# Altium schematic sheet Power.SchDoc
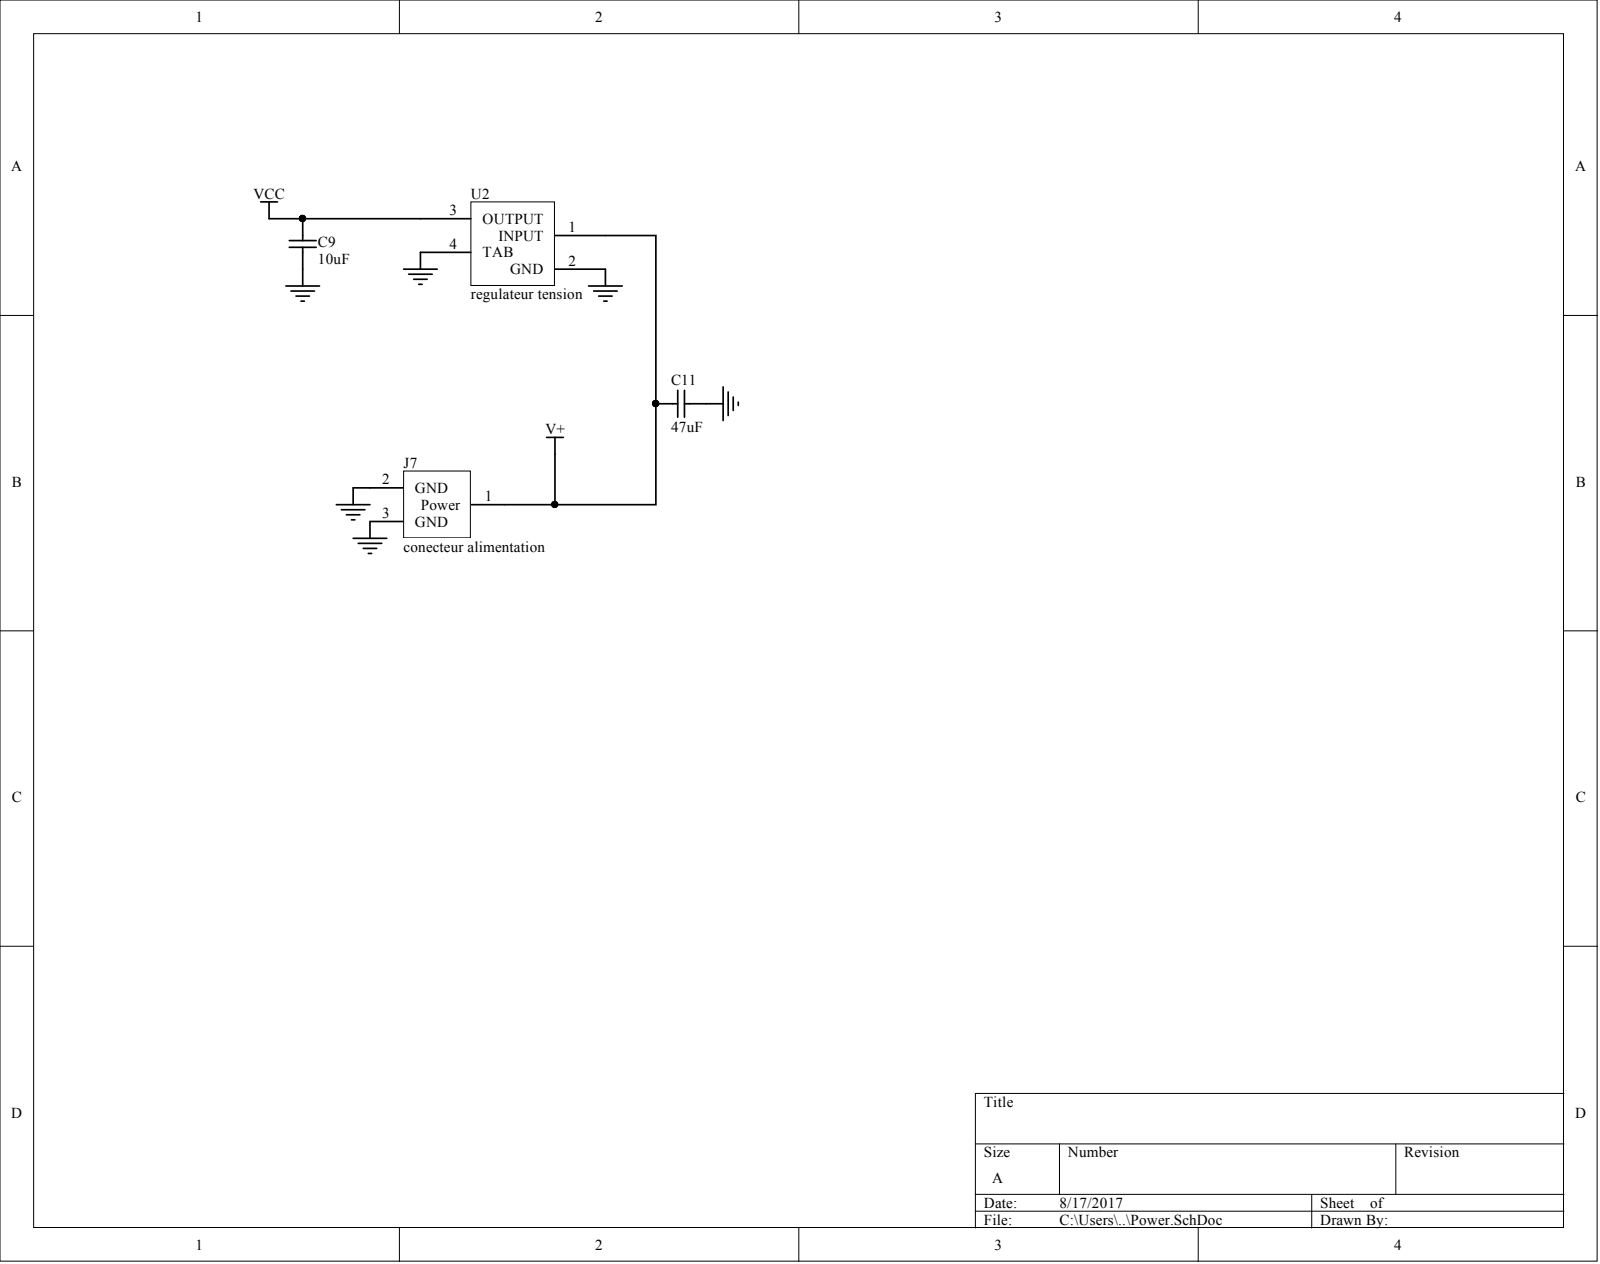
[diagram p0: Power.SchDoc]

## Parts
C9  10uF  [CAPC1608X09N]
C11  47uF  [CAPC1608X09N]
J7  conecteur alimentation
U2  regulateur tension  [SOT230P710X180-4N]

## Nets
GND: C9.1 C11.1 J7.2 J7.3 U2.2(GND) U2.4(TAB)
V+: C11.2 J7.1 U2.1(INPUT)
VCC: C9.2 U2.3(OUTPUT)

## Library data of the parts
C9 C11 = Cap: Capacitor; Value: 0.1uf; Component Kind: Standard; LatestRevisionDate: 17-Jul-2002; LatestRevisionNote: Re-released for DXP Platform.; Library Name: Miscellaneous Devices.IntLib; PackageDescription: Radial Cap, Thru-Hole; 2 Leads; 0.3 in Pin Spacing; PackageReference: RAD-0.3; Published: 8-Jun-2000; Simulation: CAP; Publisher: Altium Limited
U2 = regulateur tension: fournisseur: farnell; Ref fabricant: MIC2920A-3.3WS-TR; Ref fournisseur: 2510013; Component Kind: Standard; Library Name: lib_protoboard.SchLib
J7 = conecteur alimentation: Component Kind: Standard; Library Name: lib_protoboard.SchLib
